# Altium schematic sheet Switch.SchDoc(Switch)
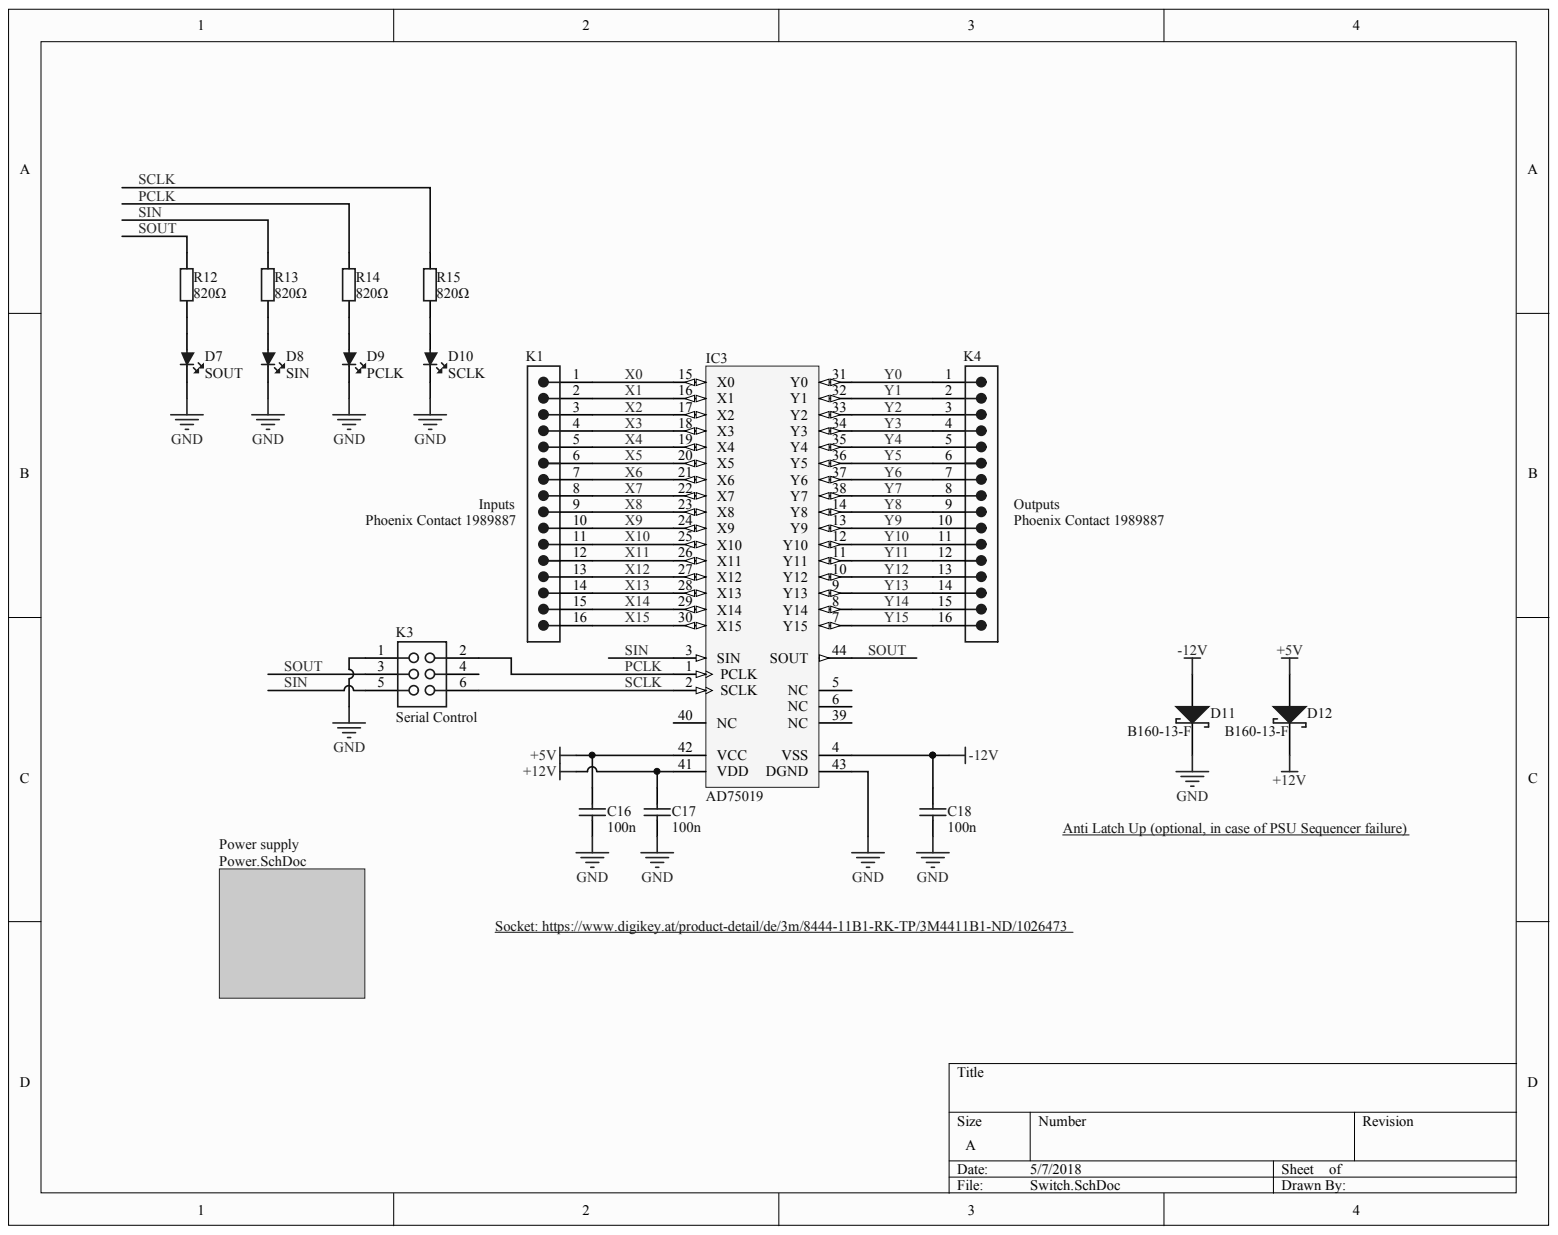
[diagram p0: Switch.SchDoc]

## Parts
C16  100n  [CAPC2013X135X45NL10T25]
C17  100n  [CAPC2013X135X45NL10T25]
C18  100n  [CAPC2013X135X45NL10T25]
D7  SOUT  [PCB-erq6vyrm5j159ejinz95-1]
D8  SIN  [PCB-erq6vyrm5j159ejinz95-1]
D9  PCLK  [PCB-erq6vyrm5j159ejinz95-1]
D10  SCLK  [PCB-erq6vyrm5j159ejinz95-1]
D11  B160-13-F  [PCB-2x3j8b784ed9qyjuzw56-1]
D12  B160-13-F  [PCB-2x3j8b784ed9qyjuzw56-1]
IC3  AD75019  [PLCC44-SOCKET]
K1  Phoenix Contact 1989887  Manufacturer Part Number: 1989887  [PCB-8jnx6l2bro2kf4qmo14o-1]
K3  Serial Control  [PCB-648449-1]
K4  Phoenix Contact 1989887  [PCB-8jnx6l2bro2kf4qmo14o-1]
R12  820Ω  [RESC2013X50X30NL20T20]
R13  820Ω  [RESC2013X50X30NL20T20]
R14  820Ω  [RESC2013X50X30NL20T20]
R15  820Ω  [RESC2013X50X30NL20T20]

## Nets
+5V: C16.2 D12.1 IC3.42(VCC)
+12V: C17.2 D12.2 IC3.41(VDD)
-12V: C18.2 D11.1 IC3.4(VSS)
GND: C16.1 C17.1 C18.1 D7.2 D8.2 D9.2 D10.2 D11.2 IC3.43(DGND) K3.1
PCLK: IC3.1(PCLK) K3.2 R14.2
SCLK: IC3.2(SCLK) K3.6 R15.2
SIN: IC3.3(SIN) K3.5 R13.2
SOUT: IC3.44(SOUT) K3.3 R12.2
X0: IC3.15(X0) K1.1
X1: IC3.16(X1) K1.2
X2: IC3.17(X2) K1.3
X3: IC3.18(X3) K1.4
X4: IC3.19(X4) K1.5
X5: IC3.20(X5) K1.6
X6: IC3.21(X6) K1.7
X7: IC3.22(X7) K1.8
X8: IC3.23(X8) K1.9
X9: IC3.24(X9) K1.10
X10: IC3.25(X10) K1.11
X11: IC3.26(X11) K1.12
X12: IC3.27(X12) K1.13
X13: IC3.28(X13) K1.14
X14: IC3.29(X14) K1.15
X15: IC3.30(X15) K1.16
Y0: IC3.31(Y0) K4.1
Y1: IC3.32(Y1) K4.2
Y2: IC3.33(Y2) K4.3
Y3: IC3.34(Y3) K4.4
Y4: IC3.35(Y4) K4.5
Y5: IC3.36(Y5) K4.6
Y6: IC3.37(Y6) K4.7
Y7: IC3.38(Y7) K4.8
Y8: IC3.14(Y8) K4.9
Y9: IC3.13(Y9) K4.10
Y10: IC3.12(Y10) K4.11
Y11: IC3.11(Y11) K4.12
Y12: IC3.10(Y12) K4.13
Y13: IC3.9(Y13) K4.14
Y14: IC3.8(Y14) K4.15
Y15: IC3.7(Y15) K4.16
NetD7_1: D7.1 R12.1
NetD8_1: D8.1 R13.1
NetD9_1: D9.1 R14.1
NetD10_1: D10.1 R15.1
NetIC3_5: IC3.5(NC)
NetIC3_6: IC3.6(NC)
NetIC3_39: IC3.39(NC)
NetIC3_40: IC3.40(NC)
NetK3_4: K3.4

## Library data of the parts
C16-C18 = CMP-db42124a01380485-1: Capacitor 10% GRM21BR71H104KA01/GRM21BR71H104KA01L MURATA RoHS Micro Farad=0.1 V=50 SO0805; Component Kind: Standard; Library Name: CommunityVault; Manufacturer Part Number: GRM21BR71H104KA01L; Size-Length: 2.00 mm
D7-D10 = CMP-1544875-6: 598 Series Green 2 x 1.25 x 0.7 mm 140° Water Clear 20 mcd 2 V LED SMT; Component Kind: Standard; Library Name: CommunityVault
D11 D12 = CMP-590c9002841686cb-4: B160 Series 60 V 1 A Surface Mount Schottky Barrier Rectifier - SMA; Capacitance: 110 pF; Current Rating: 1.00 A; Lead-Free Status: Lead Free; Output Current: 1.00 A (max); Polarity: Standard; Voltage Rating (DC): 60.0 V; Component Kind: Standard; Library Name: CommunityVault; Lifecycle Status: Not Listed by Manufacturer; Manufacturer Part Number: B160-13-F; Mounting Style: Surface Mount; Number of Pins: 2; OctopartID: 590c9002841686cb; Octopart URL: http://octopart.com/b160-13-f-diodes+inc.-325756; Packaging: Cut Tape (CT), Tape & Reel (TR); REACH SVHC Compliance: No SVHC; RoHS: Compliant; Simulation: B160
IC3 = CMP-1264743-3: 16 x 16 Crosspoint Switch Array, +/-12 V Supply, 44-pin LCC (P-44A), Tube; Lead-Free Status: Contains Lead; Case/Package: PLCC; Component Kind: Standard; Library Name: CommunityVault; OctopartID: 6acca3c19e31b50b
K1 K4 = CMP-2d10e407f67ef2d7-2: Trm Blk; 16Way/Pole; Solder Terminals; 24 to 20AWG Through Hole; Nylon; 160V; Lead-Free Status: Lead Free; Component Kind: Standard; Housing Color: Green; Library Name: CommunityVault; OctopartID: 2d10e407f67ef2d7
  K4: Manufacturer Part Number: 1989887
K3 = CMP-648449-5: CONN HEADER 6POS DL STR GOLD; Gender: Male; Lead-Free Status: Lead Free; Number of Contacts: 6; Component Kind: Standard; Contact Material: Brass; Contact Plating: Gold; Library Name: CommunityVault; Mounting Style: Through Hole; OctopartID: 31dcf8dfec8fee1b; Octopart Url: https://octopart.com/30306-6002hb-3m-653365; RoHS: Compliant
R12-R15 = CMP-1866838-2: RES SMD 820 OHM 5% 1/8W 0805; Case/Package (SI): 2012; Lead-Free Status: Lead Free; Power Rating: 0.125 W; Resistance: 820.0 Ω; Temperature Coefficient: 200.0 ppm/°C; Voltage Rating (DC): 150.0 V; Case/Package: 0805; Component Kind: Standard; Library Name: CommunityVault; Mounting Style: Surface Mount; Number of Pins: 2; OctopartID: 83e848808b6b5c2f; Octopart Url: https://octopart.com/crcw0805820rjnea-vishay+dale-39988709; Packaging: Cut Tape (CT); Resistance Tolerance: ±5%; RoHS: Compliant; Size-Height: 0.00045 m; Size-Length: 0.002 m; Size-Width: 0.00125 m
